# Altium schematic sheet Power.SchDoc
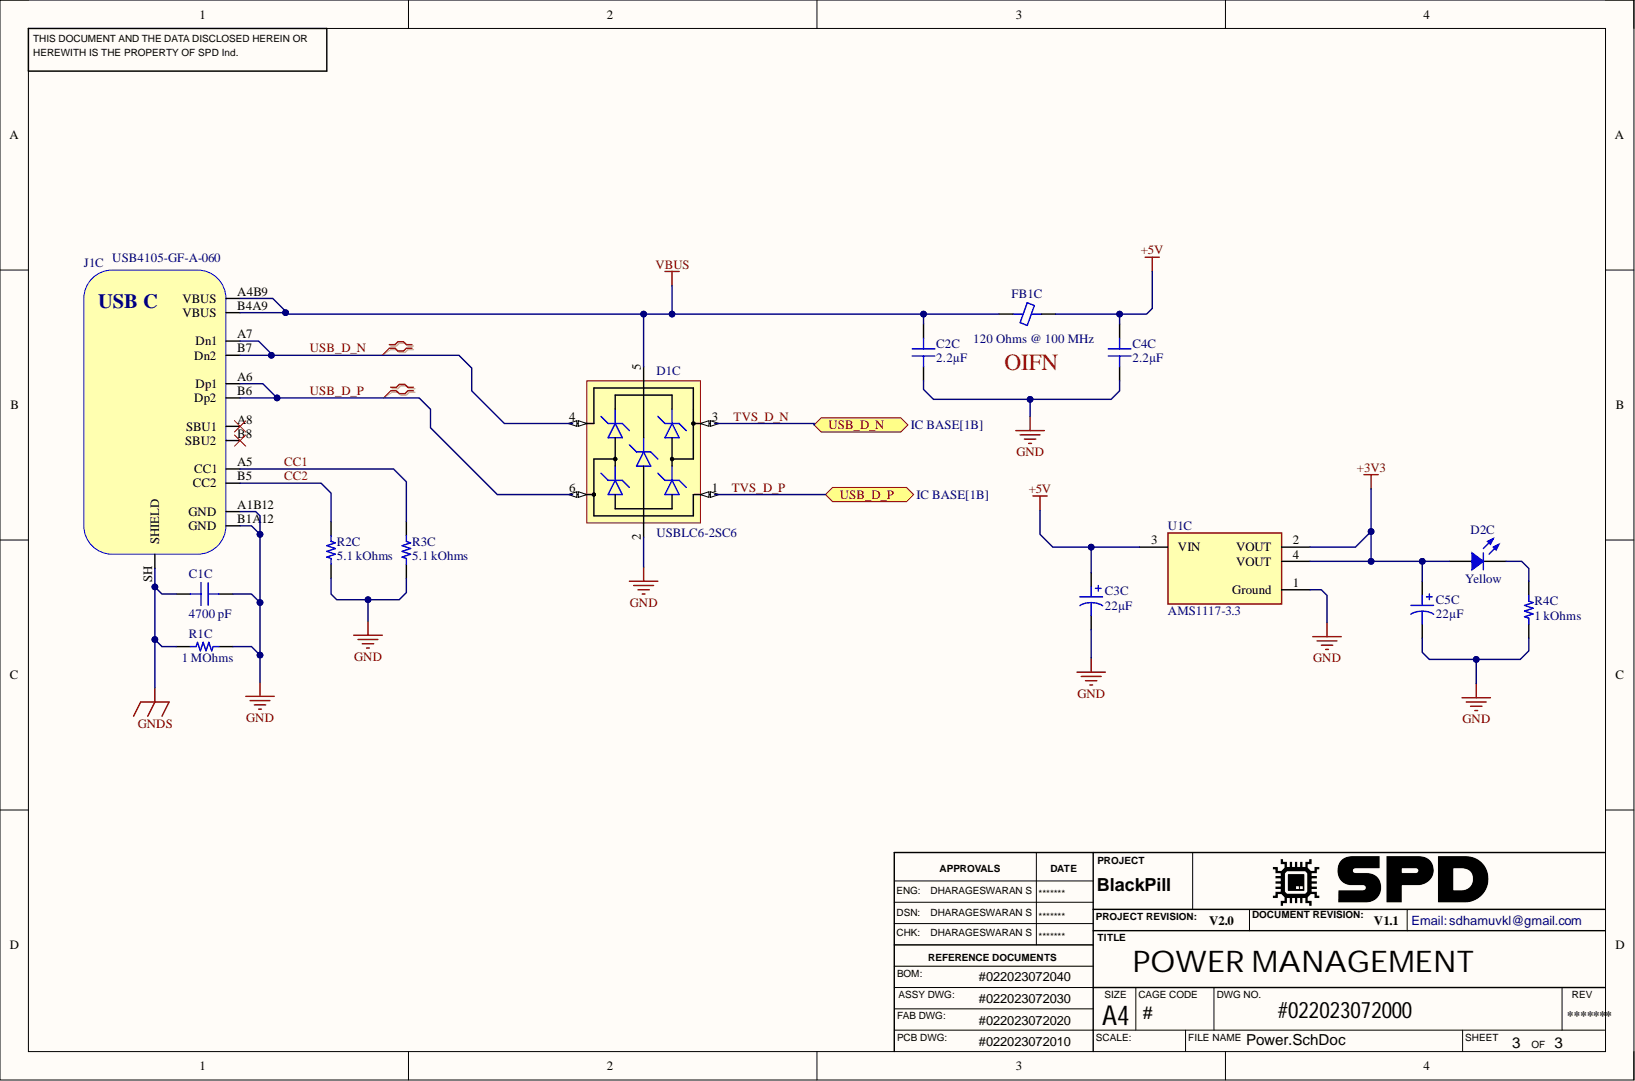
[diagram p0: Power.SchDoc]

## Parts
C1C  4700 pF  [CAP 0402_1005]
C2C  2.2µF  [CAP 0402_1005]
C3C  22µF  [CAP POL 1411_3528]
C4C  2.2µF  [CAP 0402_1005]
C5C  22µF  [CAP POL 1411_3528]
D1C  USBLC6-2SC6  [SOT-23-6]
D2C  Yellow  [LED 0402_1005 YELLOW]
FB1C  120 Ohms @ 100 MHz  [FER 0603_1608]
J1C  USB4105-GF-A-060  [USB4105-GF-A-060]
R1C  1 MOhms  [RES 0402_1005]
R2C  5.1 kOhms  [RES 0402_1005]
R3C  5.1 kOhms  [RES 0402_1005]
R4C  1 kOhms  [RES 0402_1005]
U1C  AMS1117-3.3  [FP-AMS1117-IPC_C]

## Ports
USB_D_N, USB_D_P

## Nets
+3V3: C5C.1 D2C.1 U1C.2(VOUT) U1C.4(VOUT)
+5V: C3C.1 C4C.2 FB1C.2 U1C.3(VIN)
GND: C1C.2 C2C.1 C3C.2 C4C.1 C5C.2 D1C.2 J1C.A1B12(GND) J1C.B1A12(GND) R1C.2 R2C.1 R3C.1 R4C.1 U1C.1(Ground)
GNDS: C1C.1 J1C.SH(SHIELD) R1C.1
VBUS: C2C.2 D1C.5 FB1C.1 J1C.A4B9(VBUS) J1C.B4A9(VBUS)
CC1: J1C.A5(CC1) R3C.2
CC2: J1C.B5(CC2) R2C.2
TVS_D_N: D1C.3 ; port USB_D_N
TVS_D_P: D1C.1 ; port USB_D_P
USB_D_N: D1C.4 J1C.A7(Dn1) J1C.B7(Dn2)
USB_D_P: D1C.6 J1C.A6(Dp1) J1C.B6(Dp2)
NetD2C_2: D2C.2 R4C.2

Not connected: J1C A8(SBU1) B8(SBU2)

## Library data of the parts
C1C = C0402C472K4RECAUTO: CAP CER 0402 4.7NF 16V X7R 10%; Manufacturer: KEMET; Database Table Name: altium.Capacitors-Ceramic-0402; id: 3032; Applications: Automotive, ESD Protection; Capacitance: 4700 pF; Component Kind: Standard; ComponentLink1Description: Datasheet; ComponentLink1URL: https://api.kemet.com/component-edge/download/datasheet/C0402C472K4RECAUTO.pdf; ComponentLink2Description: DigiKey Link; ComponentLink2URL: https://www.digikey.com/en/products/detail/kemet/C0402C472K4RECAUTO/8640469; Device Package: 0402 (1005 Metric); LastUpdated: 2023-01-03T20:03:46.940; Lifecycle Status: Active; Minimum Order: 1; Mounting Type: Surface Mount, MLCC; Operating Temperature: -55°C ~ 125°C; Package / Case: 0402 (1005 Metric); Packaging: TapeAndReel; Part Number: C0402C472K4RECAUTO; Part Status: Active; PartId: 12122; Price: 0.01; Ratings: AEC-Q200; Series: ESD SMD Auto X7R; Size / Dimension: 0.039" L x 0.020" W (1.00mm x 0.50mm); Supplier 1: DigiKey; Supplier Part Number 1: 399-17875-1-ND; Temperature Coefficient: X7R; Thickness (Max): 0.022" (0.55mm); Tolerance: ±10%; Value: 4700 pF; Voltage - Rated: 16V; Library Name: Postgres Altium Library - altium_library.DbLib
C2C C4C = 0402ZD225KAT2A: CAP CER 2.2UF 10V X5R 0402; Manufacturer: AVX Corporation; Database Table Name: altium.Capacitors-Ceramic-0402; id: 2335; Tolerance: ±10%; Applications: General Purpose; Capacitance: 2.2µF; Component Kind: Standard; ComponentLink1Description: Datasheet; ComponentLink1URL: http://datasheets.avx.com/cx5r.pdf; ComponentLink2Description: DigiKey Link; ComponentLink2URL: http://digikey.com/product-detail/en/avx-corporation/0402ZD225KAT2A/478-10072-1-ND/6564294; Device Package: 0402 (1005 Metric); LastUpdated: 2022-02-08T12:26:45.707; Lifecycle Status: Active; Minimum Order: 1; Mounting Type: Surface Mount, MLCC; Operating Temperature: -55°C ~ 85°C; Package / Case: 0402 (1005 Metric); Packaging: TapeAndReel; Part Number: 0402ZD225KAT2A; Part Status: Active; PartId: 9346; Price: 0.09; Series: -; Size / Dimension: 0.039" L x 0.020" W (1.00mm x 0.50mm); Supplier 1: DigiKey; Supplier Part Number 1: 478-10072-1-ND; Temperature Coefficient: X5R; Thickness (Max): 0.022" (0.56mm); Value: 2.2µF; Voltage - Rated: 10V; Library Name: Postgres Altium Library - altium_library.DbLib
C3C C5C = T540B226K010CH86107610: CAP TANT POLY 22UF 10V 1411; Manufacturer: KEMET; Manufacturer Size Code: B; Database Table Name: altium.Capacitors-Tantalum-Polymer; Esr (Equivalent Series Resistance): 80mOhm @ 100kHz; id: 2514; Lifetime @ Temp.: 2000 Hrs @ 125°C; Ratings: COTS; Tolerance: ±10%; Type: Molded; Capacitance: 22µF; Component Kind: Standard; ComponentLink1Description: Datasheet; ComponentLink1URL: https://api.kemet.com/component-edge/download/datasheet/T540B226K010CH86107610.pdf; ComponentLink2Description: DigiKey Link; ComponentLink2URL: http://digikey.com/product-detail/en/kemet/T540B226K010CH86107610/T540B226K010CH86107610-ND/6676110; Features: High Reliability; Height - Seated (Max): 0.083" (2.10mm); LastUpdated: 2020-08-30T20:56:14.177; Lifecycle Status: Active; Minimum Order: 3600; Mounting Type: Surface Mount; Operating Temperature: -55°C ~ 125°C; Package / Case: 1411 (3528 Metric); Packaging: Bulk; Part Number: T540B226K010CH86107610; PartId: 2514; Price: 9.10; Series: KO-CAP® T540; Size / Dimension: 0.138" L x 0.110" W (3.50mm x 2.80mm); Supplier 1: DigiKey; Supplier Part Number 1: T540B226K010CH86107610-ND; Value: 22µF; Voltage - Rated: 10V; Library Name: Postgres Altium Library - altium_library.DbLib
D1C = USBLC6-2SC6: Low-Capacitance ESD Protection USB; Manufacturer: STMicroelectronics; Component Kind: Standard; Distributor Link: https://www.mouser.de/ProductDetail/STMicroelectronics/USBLC6-2SC6?qs=po45yt2pPpu%2FhNIlwQdTlg%3D%3D; Library Name: Integrated_Library1.IntLib; Manufacturer Part Number: USBLC6-2SC6
D2C = SM0402YC: LED YELLOW CLEAR 0402 SMD; Manufacturer: Bivar Inc.; Configuration: 0402; Current - Test: 20mA; Database Table Name: altium.LED-Indication; id: 170; Lens Color: Colorless; Lens Size: 0.50mm x 1.00mm; Lens Style: Rectangle with Flat Top; Millicandela Rating: 145mcd; Viewing Angle: 130°; Voltage - Forward (Vf) (Typ): 2V; Wavelength - Dominant: 592nm; Wavelength - Peak: 593nm; Color: Yellow; Component Kind: Standard; ComponentLink1Description: Datasheet; ComponentLink1URL: https://www.bivar.com/Files/Datasheets/SM0402YC.pdf; ComponentLink2Description: DigiKey Link; ComponentLink2URL: https://www.digikey.com/en/products/detail/bivar-inc/SM0402YC/6810862; Device Package: 0402 (1005 Metric); Height (Max): 0.71mm; LastUpdated: 2023-01-02T20:57:30.187; Lens Transparency: Clear; Lifecycle Status: Active; Minimum Order: 1; Mounting Type: Surface Mount; Package / Case: 0402 (1005 Metric); Packaging: TapeAndReel; Part Number: SM0402YC; Part Status: Active; PartId: 170; Price: 0.16; Series: -; Size / Dimension: 1.00mm L x 0.50mm W; Supplier 1: DigiKey; Supplier Device Package: 0402; Supplier Part Number 1: 492-2206-1-ND; Value: Yellow; Library Name: Postgres Altium Library - altium_library.DbLib
FB1C = BLM18KG121TZ1D: FERRITE BEAD 120 OHM 0603 1LN; Manufacturer: Murata Electronics; Current Rating (Max): 3A; Database Table Name: altium.Filters-FerriteBeadsAndChips; DC Resistance (DCR) (Max): 30mOhm; Filter Type: Power Line; id: 635; Number Of Lines: 1; Component Kind: Standard; ComponentLink1Description: Datasheet; ComponentLink1URL: https://www.murata.com/en-us/products/productdata/8796750610462/QNFA9122.pdf; ComponentLink2Description: DigiKey Link; ComponentLink2URL: https://www.digikey.com/en/products/detail/murata-electronics/BLM18KG121TZ1D/7363244; Device Package: 0603 (1608 Metric); Height (Max): 0.030" (0.75mm); Impedance @ Frequency: 120 Ohms @ 100 MHz; LastUpdated: 2023-01-02T20:51:43.247; Lifecycle Status: Active; Minimum Order: 1; Mounting Type: Surface Mount; Operating Temperature: -55°C ~ 125°C; Package / Case: 0603 (1608 Metric); Packaging: TapeAndReel; Part Number: BLM18KG121TZ1D; Part Status: Active; PartId: 635; Price: 0.02; Ratings: AEC-Q200; Series: EMIFIL®, BLM18; Size / Dimension: 0.063" L x 0.032" W (1.60mm x 0.80mm); Supplier 1: DigiKey; Supplier Part Number 1: 490-16500-1-ND; Value: 120 Ohms @ 100 MHz; Library Name: Postgres Altium Library - altium_library.DbLib
J1C = USB4105-GF-A-060: USB Connector Type C SMT 12 Pin; Manufacturer: GCT; Component Kind: Standard; Distributor Link: https://eu.mouser.com/ProductDetail/GCT/USB4105-GF-A-060?qs=QNEnbhJQKvY2mocwNJzgfA%3D%3D; Library Name: Integrated_Library1.IntLib; Manufacturer Part Number: USB4105-GF-A-060
R1C = AC0402FR-071ML: RES SMD 1M OHM 1% 1/16W 0402; Manufacturer: Yageo; Database Table Name: altium.Resistors-SurfaceMount-0402; id: 4991; Power (Watts): 0.063W, 1/16W; Temperature Coefficient: ±100ppm/°C; Component Kind: Standard; ComponentLink1Description: Datasheet; ComponentLink1URL: https://www.yageo.com/upload/media/product/productsearch/datasheet/rchip/PYu-AC_51_RoHS_L_7.pdf; ComponentLink2Description: DigiKey Link; ComponentLink2URL: https://www.digikey.com/en/products/detail/yageo/AC0402FR-071ML/5895164; Composition: Thick Film; Device Package: 0402 (1005 Metric); Features: Automotive AEC-Q200, Moisture Resistant; Height - Seated (Max): 0.015" (0.37mm); LastUpdated: 2023-01-02T20:21:09.883; Lifecycle Status: Active; Minimum Order: 1; Number Of Terminations: 2; Operating Temperature: -55°C ~ 155°C; Package / Case: 0402 (1005 Metric); Packaging: CutTape; Part Number: AC0402FR-071ML; Part Status: Active; PartId: 12043; Price: 0.01; Ratings: AEC-Q200; Resistance: 1 MOhms; Series: AC; Size / Dimension: 0.039" L x 0.020" W (1.00mm x 0.50mm); Supplier 1: DigiKey; Supplier Device Package: 0402; Supplier Part Number 1: YAG3450CT-ND; Tolerance: ±1%; Value: 1 MOhms; Library Name: Postgres Altium Library - altium_library.DbLib
R2C R3C = AC0402FR-075K1L: RES SMD 5.1K OHM 1% 1/16W 0402; Manufacturer: Yageo; Database Table Name: altium.Resistors-SurfaceMount-0402; id: 5028; Power (Watts): 0.063W, 1/16W; Temperature Coefficient: ±100ppm/°C; Component Kind: Standard; ComponentLink1Description: Datasheet; ComponentLink1URL: https://www.yageo.com/upload/media/product/productsearch/datasheet/rchip/PYu-AC_51_RoHS_L_7.pdf; ComponentLink2Description: DigiKey Link; ComponentLink2URL: https://www.digikey.com/en/products/detail/yageo/AC0402FR-075K1L/5895438; Composition: Thick Film; Device Package: 0402 (1005 Metric); Features: Automotive AEC-Q200, Moisture Resistant; Height - Seated (Max): 0.015" (0.37mm); LastUpdated: 2023-01-02T20:21:09.567; Lifecycle Status: Active; Minimum Order: 1; Number Of Terminations: 2; Operating Temperature: -55°C ~ 155°C; Package / Case: 0402 (1005 Metric); Packaging: CutTape; Part Number: AC0402FR-075K1L; Part Status: Active; PartId: 12111; Price: 0.01; Ratings: AEC-Q200; Resistance: 5.1 kOhms; Series: AC; Size / Dimension: 0.039" L x 0.020" W (1.00mm x 0.50mm); Supplier 1: DigiKey; Supplier Device Package: 0402; Supplier Part Number 1: YAG3503CT-ND; Tolerance: ±1%; Value: 5.1 kOhms; Library Name: Postgres Altium Library - altium_library.DbLib
R4C = AA0402FR-071KL: RES SMD 1K OHM 1% 1/16W 0402; Manufacturer: Yageo; Database Table Name: altium.Resistors-SurfaceMount-0402; id: 10598; Power (Watts): 0.063W, 1/16W; Temperature Coefficient: ±150ppm/°C; Component Kind: Standard; ComponentLink1Description: Datasheet; ComponentLink1URL: https://www.yageo.com/upload/media/product/productsearch/datasheet/rchip/PYu-AA_51_RoHS_L_3.pdf; ComponentLink2Description: DigiKey Link; ComponentLink2URL: https://www.digikey.com/en/products/detail/yageo/AA0402FR-071KL/5887878; Composition: Thick Film; Device Package: 0402 (1005 Metric); Features: Anti-Sulfur, Automotive AEC-Q200, Moisture Resistant; Height - Seated (Max): 0.015" (0.37mm); LastUpdated: 2023-01-02T20:20:10.210; Lifecycle Status: Active; Minimum Order: 1; Number Of Terminations: 2; Operating Temperature: -55°C ~ 155°C; Package / Case: 0402 (1005 Metric); Packaging: TapeAndReel; Part Number: AA0402FR-071KL; Part Status: Active; PartId: 59178; Price: 0.01; Ratings: AEC-Q200; Resistance: 1 kOhms; Series: AA; Size / Dimension: 0.039" L x 0.020" W (1.00mm x 0.50mm); Supplier 1: DigiKey; Supplier Device Package: 0402; Supplier Part Number 1: 13-AA0402FR-071KLCT-ND; Tolerance: ±1%; Value: 1 kOhms; Library Name: Postgres Altium Library - altium_library.DbLib
U1C = CMP-209535-000001-1: LDO Voltage Regulators 1A, 3.3V; Manufacturer: Advanced Monolithic Systems; Dropout Voltage: 1.1V; Max Junction Temp: +125°C; Max Power Dissipation: 1.2W; Max Supply Voltage: 15V; Nominal Supply Current: 5mA; Number Of Outputs: 1; Output Type: Fixed; Standoff Height: 0.64mm; Automotive: No; Category: IC; Component Kind: Standard; ComponentLink2Description: Datasheet; ComponentLink2URL: http://www.advanced-monolithic.com/pdf/ds1117.pdf; Device Class L1: Integrated Circuits (ICs); Device Class L2: Power Management ICs; Device Class L3: Voltage Regulators - Linear; Height: 1.8mm; Ipc Land Pattern Name: SOT229P700X180-4; Lead Free: Yes; Library Name: Altium Content Vault; Manufacturer Part Number: AMS1117-3.3; Octopart: https://octopart.com/ams1117-3.3-advanced+monolithic+systems-25899300; Output Current: 1A; Output Voltage: 3.3V; Package: AMS1117; RoHS: TRUE; Temperature: -40°C to +125°C; Temperature Range High: +125°C; Temperature Range Low: -40°C
